# Altium schematic sheet SIB Temp.SchDoc
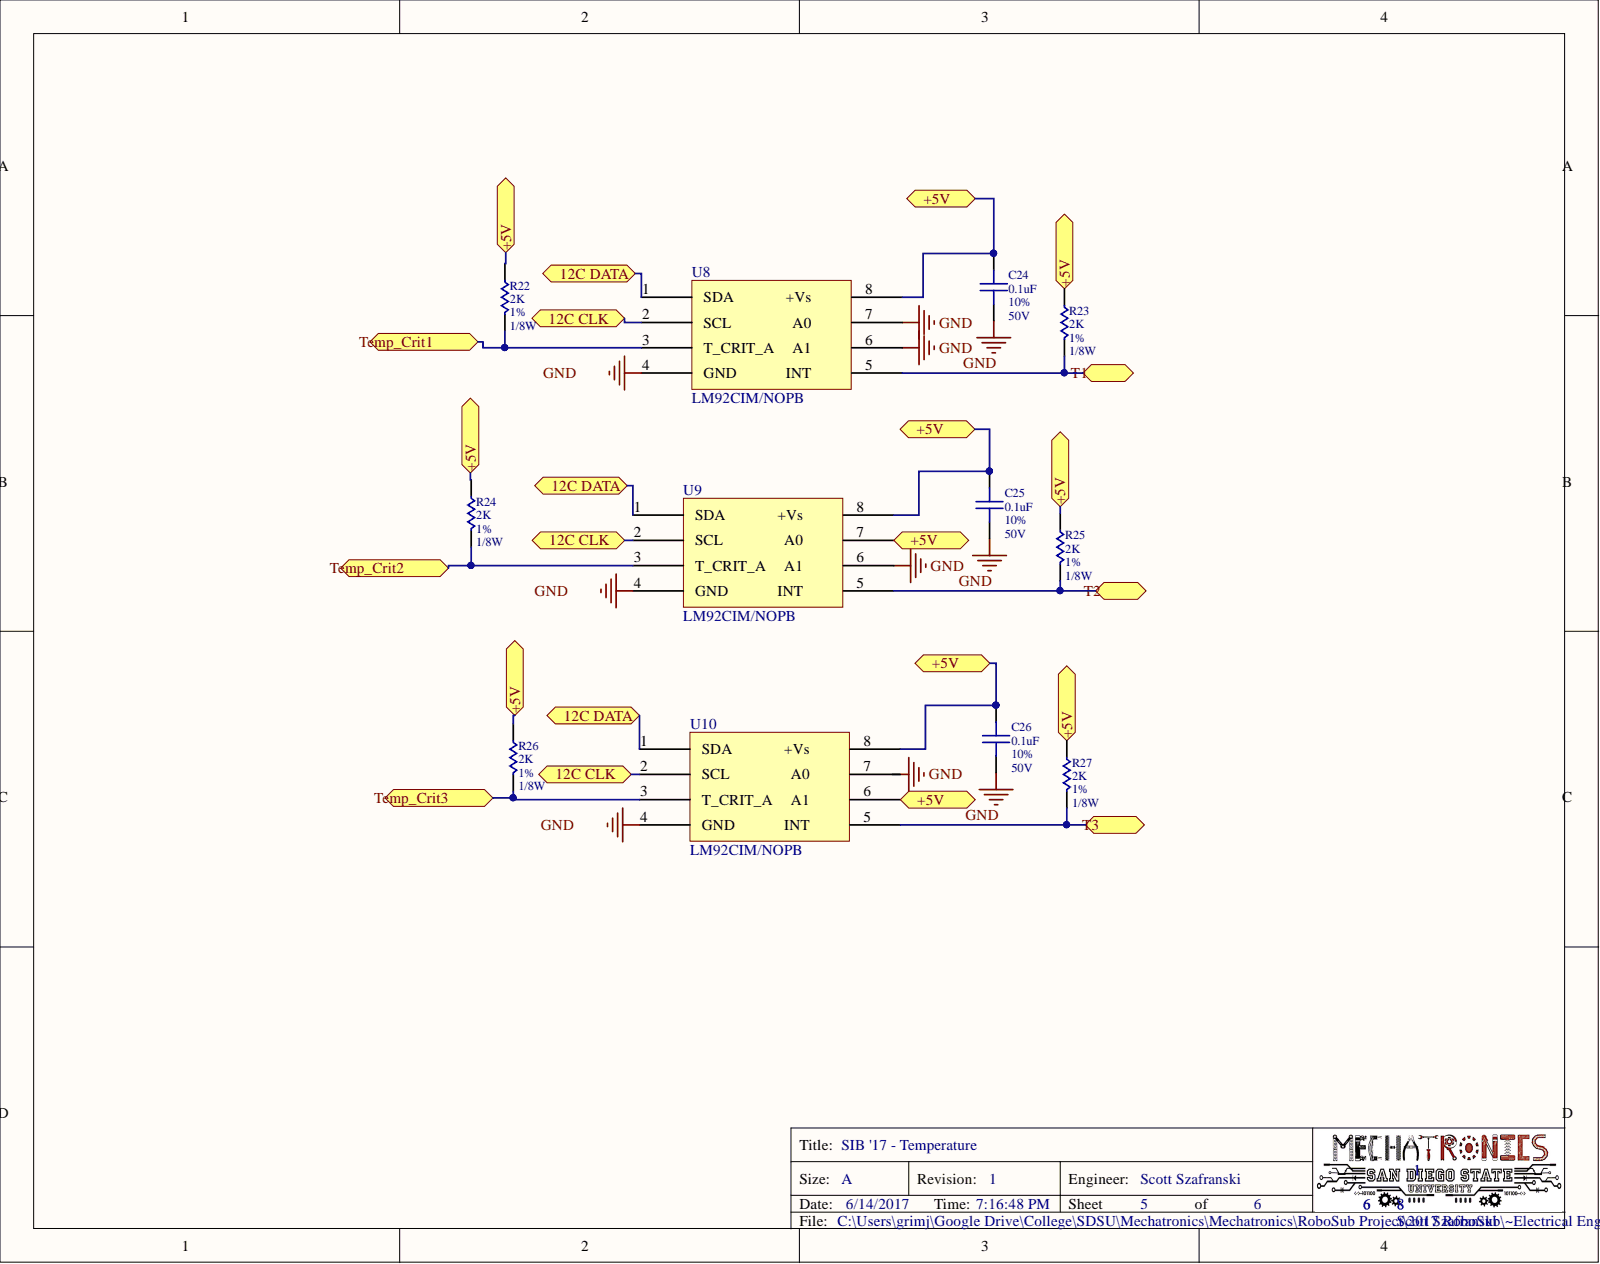
[diagram p0: SIB Temp.SchDoc]

## Parts
C24  0.1uF  Tolerance: 10%  Voltage: 50V  [Component 0805]
C25  0.1uF  Tolerance: 10%  Voltage: 50V  [Component 0805]
C26  0.1uF  Tolerance: 10%  Voltage: 50V  [Component 0805]
R22  2K  Power: 1/8W  Tolerance: 1%  [Component 0805]
R23  2K  Power: 1/8W  Tolerance: 1%  [Component 0805]
R24  2K  Power: 1/8W  Tolerance: 1%  [Component 0805]
R25  2K  Power: 1/8W  Tolerance: 1%  [Component 0805]
R26  2K  Power: 1/8W  Tolerance: 1%  [Component 0805]
R27  2K  Power: 1/8W  Tolerance: 1%  [Component 0805]
U8  LM92CIM/NOPB  [SOIC 8]
U9  LM92CIM/NOPB  [SOIC 8]
U10  LM92CIM/NOPB  [SOIC 8]

## Ports
12C CLK, 12C DATA, +5V, T1, T2, T3, TEMP_CRIT1, TEMP_CRIT2, TEMP_CRIT3

## Nets
GND: C24.2 C25.2 C26.2 U8.4(GND) U8.6 U8.7 U9.4(GND) U9.6 U10.4(GND)
12C CLK: U8.2(SCL) U9.2(SCL) U10.2(SCL) ; port 12C CLK
12C DATA: U8.1(SDA) U9.1(SDA) U10.1(SDA) ; port 12C DATA
+5V: C24.1 C25.1 C26.1 R22.1 R23.1 R24.1 R25.1 R26.1 R27.1 U8.8 U9.7 U9.8 U10.6 U10.8 ; port +5V
T1: R23.2 U8.5 ; port T1
T2: R25.2 U9.5 ; port T2
T3: R27.2 U10.5 ; port T3
NetR22_2: R22.2 U8.3(T_CRIT_A) ; port TEMP_CRIT1
NetR24_2: R24.2 U9.3(T_CRIT_A) ; port TEMP_CRIT2
NetR26_2: R26.2 U10.3(T_CRIT_A) ; port TEMP_CRIT3

Not connected: U10 7

## Library data of the parts
C24-C26 = Capacitor, Ceramic: Capacitance: 0.1uF; Component Kind: Standard; Library Name: MechatronicsSCH.SchLib
R22-R27 = Resistor: Thick Film Resistors - SMD 1/16watt 1%; Component Kind: Standard; Resistance: 2K; Library Name: MechatronicsSCH.SchLib
U8-U10 = LM92CIM/NOPB: Temperature Sensor - http://www.digikey.com/product-detail/en/LM92CIM%2FNOPB/LM92CIM%2FNOPB-ND/364288; Component Kind: Standard; Library Name: My Parts Sch Components.SchLib
